# Altium schematic sheet 11ethernetGbit.SchDoc
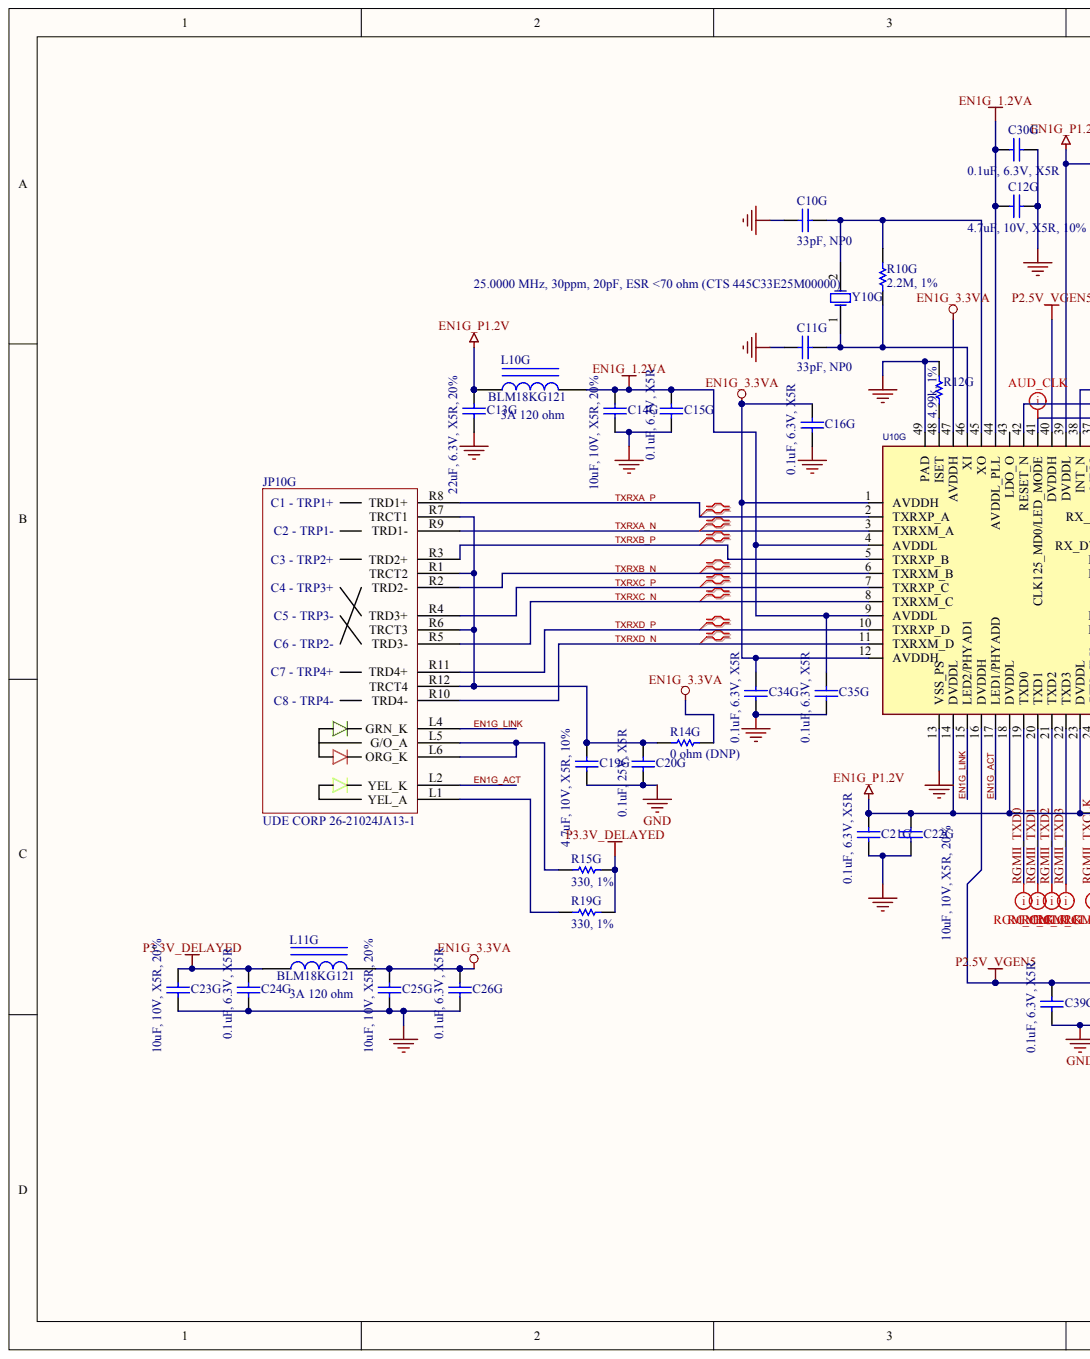
[diagram p0: 11ethernetGbit.SchDoc, left]
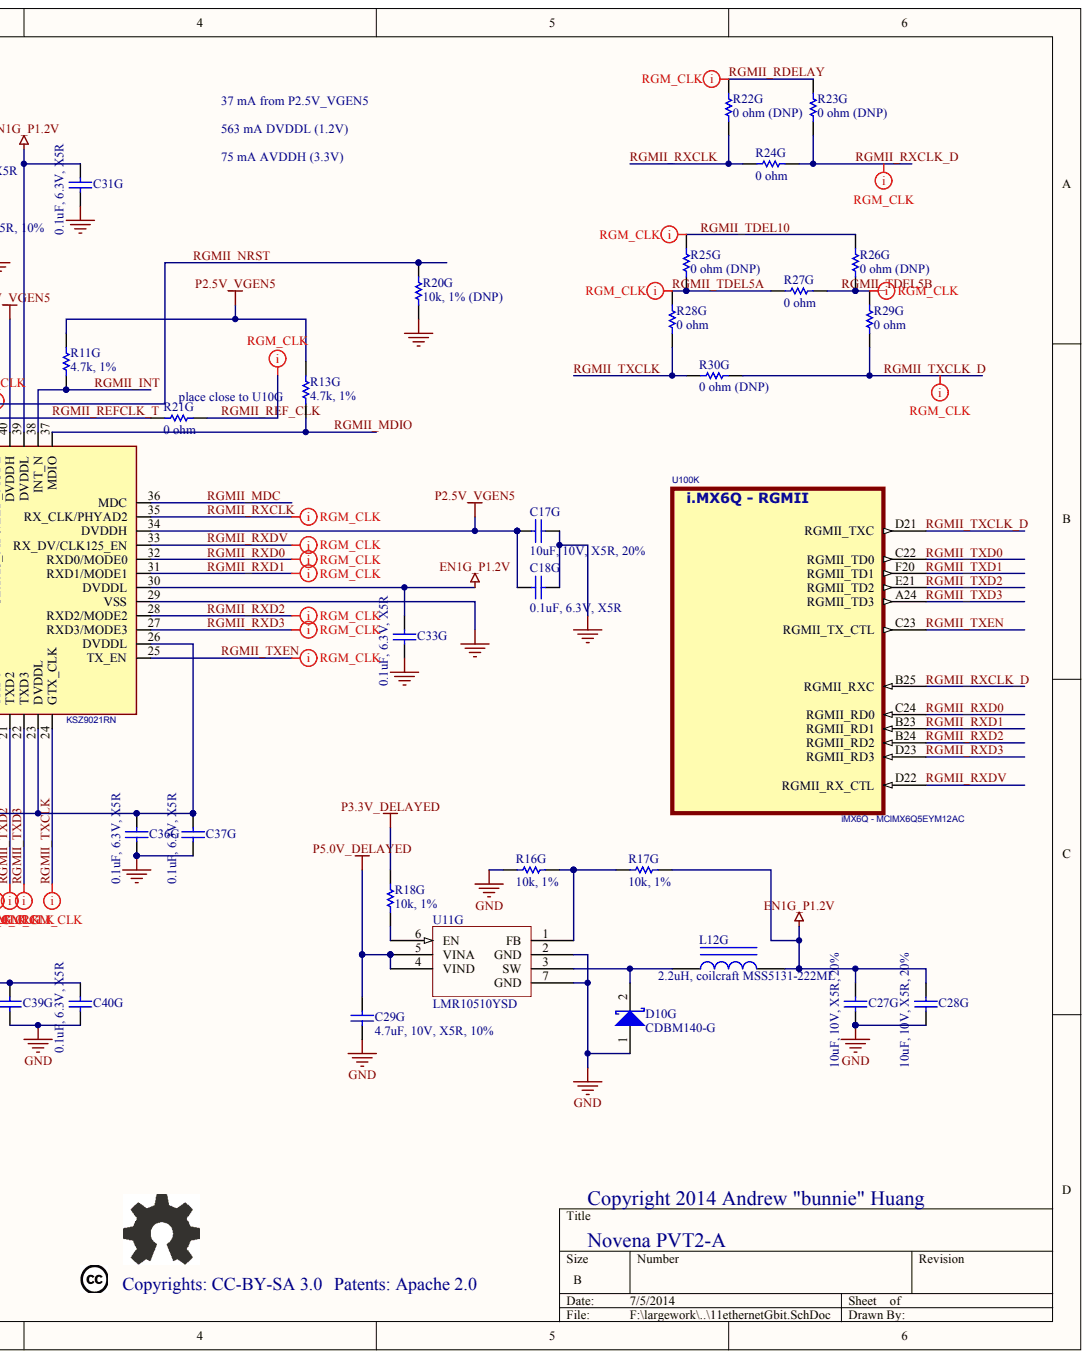
[diagram p1: 11ethernetGbit.SchDoc, right]

## Parts
C10G  33pF, NP0
C11G  33pF, NP0
C12G  4.7uF, 10V, X5R, 10%
C13G  22uF, 6.3V, X5R, 20%
C14G  10uF, 10V, X5R, 20%
C15G  0.1uF, 6.3V, X5R
C16G  0.1uF, 6.3V, X5R
C17G  10uF, 10V, X5R, 20%
C18G  0.1uF, 6.3V, X5R
C19G  4.7uF, 10V, X5R, 10%
C20G  0.1uF, 25V, X5R
C21G  0.1uF, 6.3V, X5R
C22G  10uF, 10V, X5R, 20%
C23G  10uF, 10V, X5R, 20%
C24G  0.1uF, 6.3V, X5R
C25G  10uF, 10V, X5R, 20%
C26G  0.1uF, 6.3V, X5R
C27G  10uF, 10V, X5R, 20%
C28G  10uF, 10V, X5R, 20%
C29G  4.7uF, 10V, X5R, 10%
C30G  0.1uF, 6.3V, X5R
C31G  0.1uF, 6.3V, X5R
C33G  0.1uF, 6.3V, X5R
C34G  0.1uF, 6.3V, X5R
C35G  0.1uF, 6.3V, X5R
C36G  0.1uF, 6.3V, X5R
C37G  0.1uF, 6.3V, X5R
C39G  0.1uF, 6.3V, X5R
C40G  0.1uF, 6.3V, X5R
D10G  CDBM140-G
JP10G  UDE CORP 26-21024JA13-1
L10G  BLM18KG121  value 3A 120 ohm
L11G  BLM18KG121  value 3A 120 ohm
L12G  2.2uH, coilcraft MSS5131-222ML
R10G  2.2M, 1%
R11G  4.7k, 1%
R12G  4.99k, 1%
R13G  4.7k, 1%
R14G  0 ohm (DNP)  (value not drawn)
R15G  330, 1%
R16G  10k, 1%
R17G  10k, 1%
R18G  10k, 1%
R19G  330, 1%
R20G  10k, 1% (DNP)
R21G  0 ohm
R22G  0 ohm (DNP)
R23G  0 ohm (DNP)
R24G  0 ohm
R25G  0 ohm (DNP)
R26G  0 ohm (DNP)
R27G  0 ohm
R28G  0 ohm
R29G  0 ohm
R30G  0 ohm (DNP)
U10G  KSZ9021RN
U11G  LMR10510YSD
U100  iMX6Q - MCIMX6Q5EYM12AC  (part K)
Y10G  25.0000 MHz, 30ppm, 20pF, ESR <70 ohm (CTS 445C33E25M00000)

## Nets
EN1G_1.2VA: C12G.1 C14G.1 C15G.1 C30G.2 C35G.1 L10G.2 U10G.4(AVDDL) U10G.9(AVDDL) U10G.44(AVDDL_PLL)
EN1G_3.3VA: C16G.1 C25G.1 C26G.1 C34G.1 L11G.2 R14G.2 U10G.1(AVDDH) U10G.12(AVDDH) U10G.47(AVDDH)
EN1G_P1.2V: C13G.1 C21G.1 C22G.1 C27G.2 C28G.2 C31G.1 C33G.1 C36G.1 C37G.1 L10G.1 L12G.2 R17G.1 U10G.14(DVDDL) U10G.18(DVDDL) U10G.23(DVDDL) U10G.26(DVDDL) U10G.30(DVDDL) U10G.39(DVDDL)
GND: C10G.1 C11G.1 C12G.2 C13G.2 C14G.2 C15G.2 C16G.2 C17G.2 C18G.2 C19G.2 C20G.2 C21G.2 C22G.2 C23G.2 C24G.2 C25G.2 C26G.2 C27G.1 C28G.1 C29G.2 C30G.1 C31G.2 C33G.2 C34G.2 C35G.2 C36G.2 C37G.2 C39G.2 C40G.2 D10G.1 R12G.2 R16G.2 R20G.1 U10G.13(VSS_PS) U10G.29(VSS) U10G.49(PAD) U11G.2(GND) U11G.7(GND)
P2.5V_VGEN5: C17G.1 C18G.1 C39G.1 C40G.1 R11G.2 R13G.2 U10G.16(DVDDH) U10G.34(DVDDH) U10G.40(DVDDH)
P3.3V_DELAYED: C23G.1 C24G.1 L11G.1 R15G.2 R18G.2 R19G.2
P5.0V_DELAYED: C29G.1 U11G.4(VIND) U11G.5(VINA)
EN1G_ACT: JP10G.L2(YEL_K) U10G.17(LED1/PHYADD)
EN1G_LINK: JP10G.L4(GRN_K) U10G.15(LED2/PHYAD1)
RGMII_INT: R11G.1 U10G.38(INT_N)
RGMII_MDC: U10G.36(MDC)
RGMII_MDIO: R13G.1 U10G.37(MDIO)
RGMII_NRST: R20G.2 U10G.42(RESET_N)
RGMII_RDELAY: R22G.2 R23G.2
RGMII_REF_CLK: R21G.2
RGMII_REFCLK_T: R21G.1 U10G.41(CLK125_MD0/LED_MODE)
RGMII_RXCLK: R22G.1 R24G.1 U10G.35(RX_CLK/PHYAD2)
RGMII_RXCLK_D: R23G.1 R24G.2 U100.B25(RGMII_RXC)
RGMII_RXD0: U10G.32(RXD0/MODE0) U100.C24(RGMII_RD0)
RGMII_RXD1: U10G.31(RXD1/MODE1) U100.B23(RGMII_RD1)
RGMII_RXD2: U10G.28(RXD2/MODE2) U100.B24(RGMII_RD2)
RGMII_RXD3: U10G.27(RXD3/MODE3) U100.D23(RGMII_RD3)
RGMII_RXDV: U10G.33(RX_DV/CLK125_EN) U100.D22(RGMII_RX_CTL)
RGMII_TDEL5A: R25G.1 R27G.2 R28G.2
RGMII_TDEL5B: R26G.1 R27G.1 R29G.2
RGMII_TDEL10: R25G.2 R26G.2
RGMII_TXCLK: R28G.1 R30G.1 U10G.24(GTX_CLK)
RGMII_TXCLK_D: R29G.1 R30G.2 U100.D21(RGMII_TXC)
RGMII_TXD0: U10G.19(TXD0) U100.C22(RGMII_TD0)
RGMII_TXD1: U10G.20(TXD1) U100.F20(RGMII_TD1)
RGMII_TXD2: U10G.21(TXD2) U100.E21(RGMII_TD2)
RGMII_TXD3: U10G.22(TXD3) U100.A24(RGMII_TD3)
RGMII_TXEN: U10G.25(TX_EN) U100.C23(RGMII_TX_CTL)
TXRXA_N: JP10G.R9(TRD1-) U10G.3(TXRXM_A)
TXRXA_P: JP10G.R8(TRD1+) U10G.2(TXRXP_A)
TXRXB_N: JP10G.R2(TRD2-) U10G.6(TXRXM_B)
TXRXB_P: JP10G.R3(TRD2+) U10G.5(TXRXP_B)
TXRXC_N: JP10G.R5(TRD3-) U10G.8(TXRXM_C)
TXRXC_P: JP10G.R4(TRD3+) U10G.7(TXRXP_C)
TXRXD_N: JP10G.R10(TRD4-) U10G.11(TXRXM_D)
TXRXD_P: JP10G.R11(TRD4+) U10G.10(TXRXP_D)
NetC10G_2: C10G.2 R10G.1 U10G.45(XO) Y10G.2
NetC11G_2: C11G.2 R10G.2 U10G.46(XI) Y10G.1
NetC19G_1: C19G.1 C20G.1 JP10G.R1(TRCT2) JP10G.R6(TRCT3) JP10G.R7(TRCT1) JP10G.R12(TRCT4) R14G.1
NetD10G_2: D10G.2 L12G.1 U11G.3(SW)
NetJP10G_L1: JP10G.L1(YEL_A) R19G.1
NetJP10G_L5: JP10G.L5(G/O_A) JP10G.L6(ORG_K) R15G.1
NetR12G_1: R12G.1 U10G.48(ISET)
NetR16G_1: R16G.1 R17G.2 U11G.1(FB)
NetR18G_1: R18G.1 U11G.6(EN)

Not connected: U10G 43(LDO_O)

## Library data of the parts
D10G = (no symbol name): Code - JEDEC: DO-214-AA; Published: 8-Jun-2000; Revision: July-2002: Re-released for DXP Platform.; Publisher: Altium Limited
L10G L11G = (no symbol name): Value: 3A 120 ohm; Published: 24-Mar-1999; LatestRevisionDate: 17-Jul-2002; LatestRevisionNote: Re-released for DXP Platform.; PackageReference: AXIAL-0.9; Publisher: Altium Limited
R10G R11G R12G R13G R14G R15G R16G R17G R18G R19G R20G R21G R22G R23G R24G R25G R26G R27G R28G R29G R30G = (no symbol name): Value: 100k; Published: 8-Jun-2000; LatestRevisionDate: 17-Jul-2002; LatestRevisionNote: Re-released for DXP Platform.; PackageReference: AXIAL-0.3; Publisher: Altium Limited
U100 = (no symbol name): AGILE_PN: TMP-WF-14526; MFG_NAME01: Freescale Semiconductor; MFG_PN01: PCIMX6Q6VV1A; PRE_APN: 344-01218; ROHS: Yes; HEIGHT: 2.16MM
C10G C11G C12G C13G C14G C15G C16G C17G C18G C19G C20G C21G C22G C23G C24G C25G C26G C27G C28G C29G C30G C31G C33G C34G C35G C36G C37G C39G C40G JP10G L12G U10G U11G Y10G = (no symbol name)
  C10G C11G C12G C13G C14G C15G C16G C17G C18G C19G C20G C21G C22G C23G C24G C25G C26G C27G C28G C29G C30G C31G C33G C34G C35G C36G C37G C39G C40G: Published: 8-Jun-2000; LatestRevisionDate: 17-Jul-2002; LatestRevisionNote: Re-released for DXP Platform.; PackageReference: C1206
  L12G: Published: 24-Mar-1999; LatestRevisionDate: 17-Jul-2002; LatestRevisionNote: Re-released for DXP Platform.; PackageReference: AXIAL-0.9
  Y10G: Published: 8-Jun-2000; LatestRevisionDate: 17-Jul-2002; LatestRevisionNote: Re-released for DXP Platform.; PackageReference: R38
  C10G C11G C12G C13G C14G C15G C16G C17G C18G C19G C20G C21G C22G C23G C24G C25G C26G C27G C28G C29G C30G C31G C33G C34G C35G C36G C37G C39G C40G L12G Y10G: Publisher: Altium Limited
